# Altium schematic sheet schematic2.SchDoc
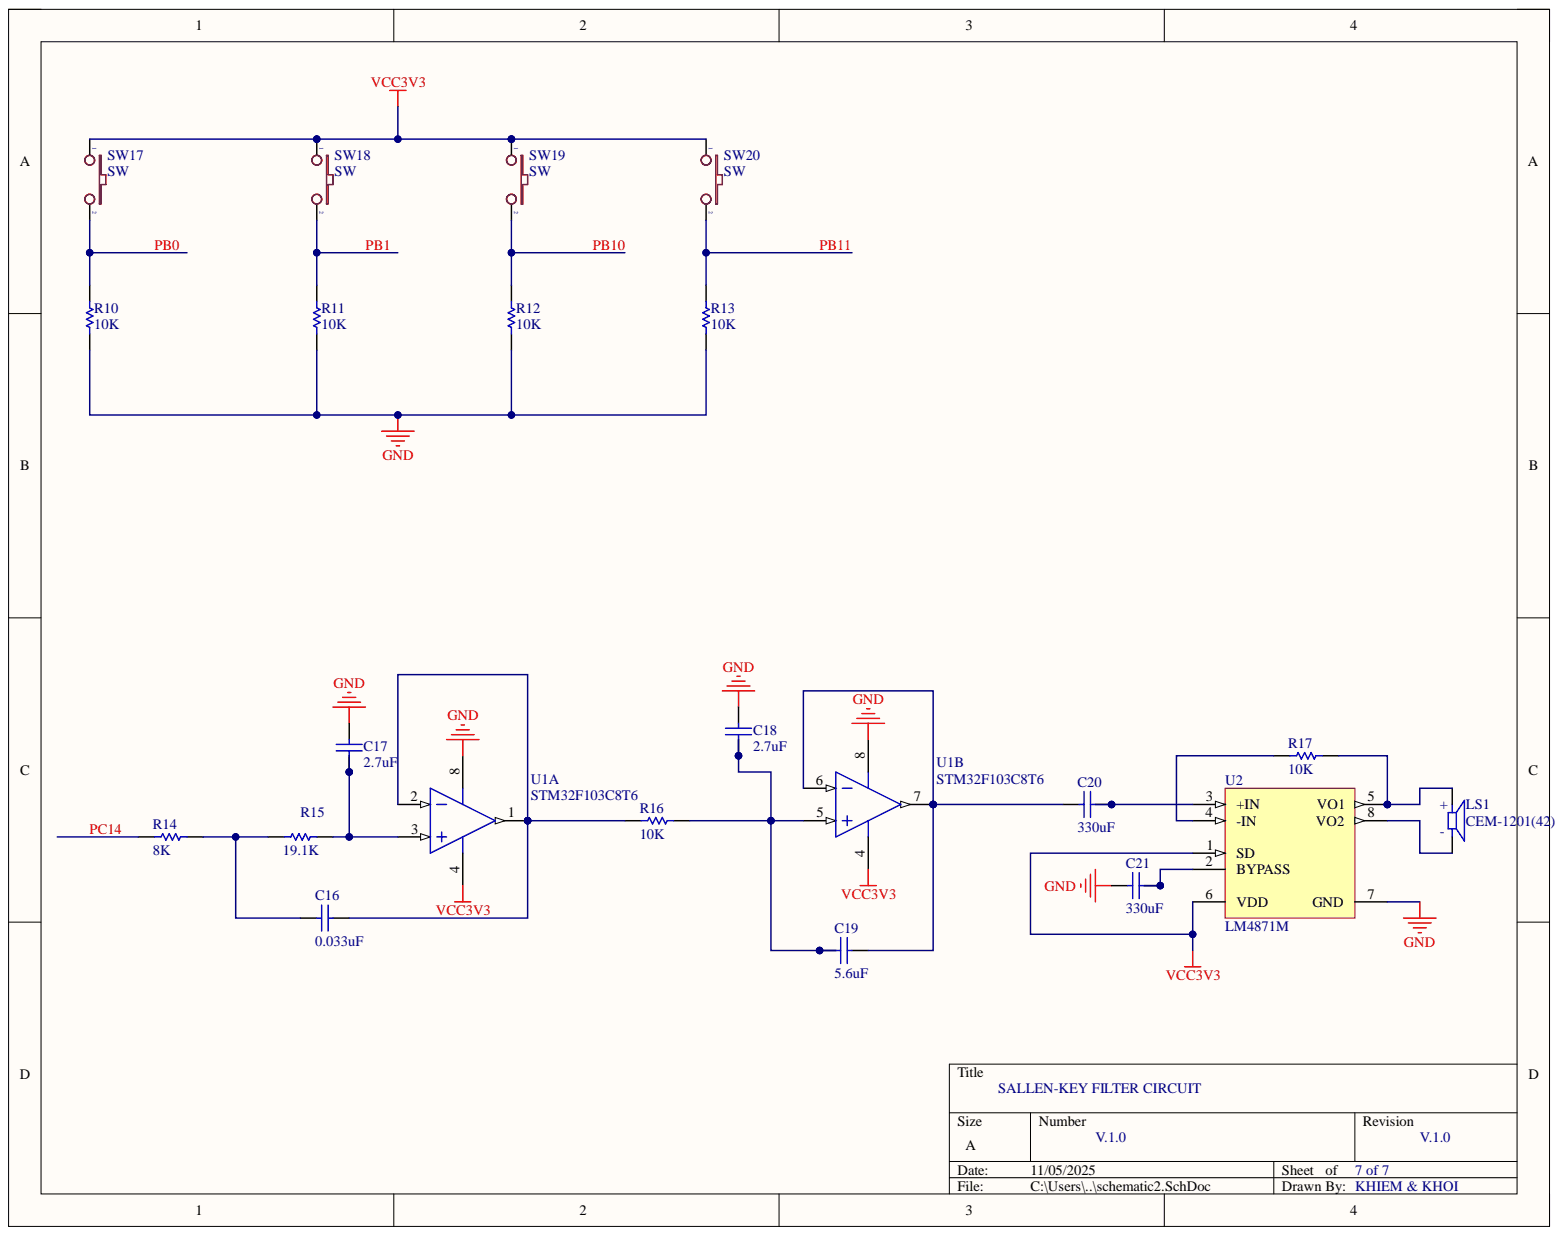
[diagram p0: schematic2.SchDoc]

## Parts
C16  value 0.033uF  [tu hoa 4mm]  (hidden comment: Capacitor)
C17  value 2.7uF  [tu hoa 4mm]  (hidden comment: Capacitor)
C18  value 2.7uF  [tu hoa 4mm]  (hidden comment: Capacitor)
C19  value 5.6uF  [tu hoa 4mm]  (hidden comment: Capacitor)
C20  value 330uF  [tu hoa 4mm]  (hidden comment: Capacitor)
C21  value 330uF  [tu hoa 4mm]  (hidden comment: Capacitor)
LS1  CEM-1201(42)  [CUI_CEM-1201(42)]
R10  value 10K  [R1/8W]  (hidden comment: Resistor)
R11  value 10K  [R1/8W]  (hidden comment: Resistor)
R12  value 10K  [R1/8W]  (hidden comment: Resistor)
R13  value 10K  [R1/8W]  (hidden comment: Resistor)
R14  value 8K  [R1/8W]  (hidden comment: Resistor)
R15  value 19.1K  [R1/8W]  (hidden comment: Resistor)
R16  value 10K  [R1/8W]  (hidden comment: Resistor)
R17  value 10K  [R1/8W]  (hidden comment: Resistor)
SW17  SW  [SW2]
SW18  SW  [SW2]
SW19  SW  [SW2]
SW20  SW  [SW2]
U1  STM32F103C8T6  [PDIP300-P8]  (parts A,B)
U2  LM4871M  [D0008A_N]

## Nets
GND: C17.2 C18.2 C21.1 R10.1 R11.1 R12.1 R13.1 U1.8 U2.7(GND)
VCC3V3: SW17.1 SW18.1 SW19.1 SW20.1 U1.4 U2.1(SD) U2.6(VDD)
PB0: R10.2 SW17.2
PB1: R11.2 SW18.2
PB10: R12.2 SW19.2
PB11: R13.2 SW20.2
PC14: R14.1
NetC16_1: C16.1 R14.2 R15.1
NetC16_2: C16.2 R16.1 U1.1 U1.2
NetC17_1: C17.1 R15.2 U1.3
NetC18_1: C18.1 C19.1 R16.2 U1.5
NetC19_2: C19.2 C20.1 U1.6 U1.7
NetC20_2: C20.2 U2.3(+IN)
NetC21_2: C21.2 U2.2(BYPASS)
NetLS1_M: LS1.M U2.8(VO2)
NetLS1_P: LS1.P R17.2 U2.5(VO1)
NetR17_1: R17.1 U2.4(-IN)

## Library data of the parts
C16-C21 = Capacitor: SimulationGenericComponent: True; Component Kind: Standard; Description: Capacitor; Library Name: Simulation Generic Components.IntLib; Simulation: C_Capacitor_Default
LS1 = CEM-1201(42): Audio Buzzer Magnetic 1Vo-p 2Vo-p 20mA 1.5Vo-p 85dBA Pin Through Hole Tray; Availability: Unavailable; Component Kind: Standard; Library Name: CEM-1201_42_.IntLib; MP: CEM-1201(42); Package: None; Price: None
R10-R17 = Resistor: SimulationGenericComponent: True; TC1: 0; Component Kind: Standard; Description: Resistor; Library Name: Simulation Generic Components.IntLib; Simulation: R_Resistor_Default; TC2: 0
SW17-SW20 = SW2: Switch button 2 pin; Value: SW chip 2 pin; Component Kind: Standard; Library Name: syhaunguyen.IntLib
U1 = CMP-0187-00090-3: None; Amplifier Type: GENERAL PURPOSE; Bandwidth: 10MHz; Common Mode Rejection Ratio: 70dB; Input Bias Current: 5nA; Input Offset Voltage (Vos): 250uV; Input Offset Voltage-Max: 500uV; Max Supply Voltage: 5.5V; Min Supply Voltage: 2.5V; Nominal Supply Current: 1mA; Number of Amplifiers: 2; Output Current: 22mA; Power Supply Rejection Ratio (PSRR): 74dB; Slew Rate: 7V/µs; Voltage Gain: 110dB; Case/Package: PDIP; Component Kind: Standard; Gain Bandwidth Product: 10MHz; Harmonic Distortion: 0.00053%; Height: 0.195inch; Lead Free: Lead Free; Length: 0.4inch; Library Name: Altium Content Vault; Max Operating Temperature: 125°C; Min Operating Temperature: -40°C; Mount: Through Hole; Number of Channels: 2; Number of Circuits: 2; Number of Elements: 2; Number of Pins: 8; Package Quantity: 60; Packaging: Rail/Tube; Radiation Hardening: No; REACH SVHC: No SVHC; RoHS Compliant: Yes; Slew Rate-Nom: 7V/µs; Supply Current: 1mA; Technology: CMOS; Unity Gain Bandwidth Product: 10MHz; Width: 0.28inch
U2 = CMP-0057-00345-3: 3W Audio Power Amplifier with Shutdown Mode, 8-pin Narrow SOIC; Manufacturer: Texas Instruments; CompType: Audio IC; PackageTopMarking: NSZXTT*4871; PowerWise: N; Code_IPC: SOIC127P600-8; Code_JEDEC: MS-012-AA; Component Kind: Standard; ComponentLink1Description: Datasheet URL; ComponentLink1URL: http://www.ti.com/general/docs/lit/getliterature.tsp?genericPartNumber=LM4871&fileType=pdf; Library Name: Altium Content Vault; PackageDescription: SOIC Narrow, 4.9 x 3.9 x 1.45mm, 8 Lead, 1.27mm Pitch; PackageReference: D0008A; PinCount: 8; RoHS: N
